# Altium schematic sheet ProperBMS.SchDoc(ProperBMS)
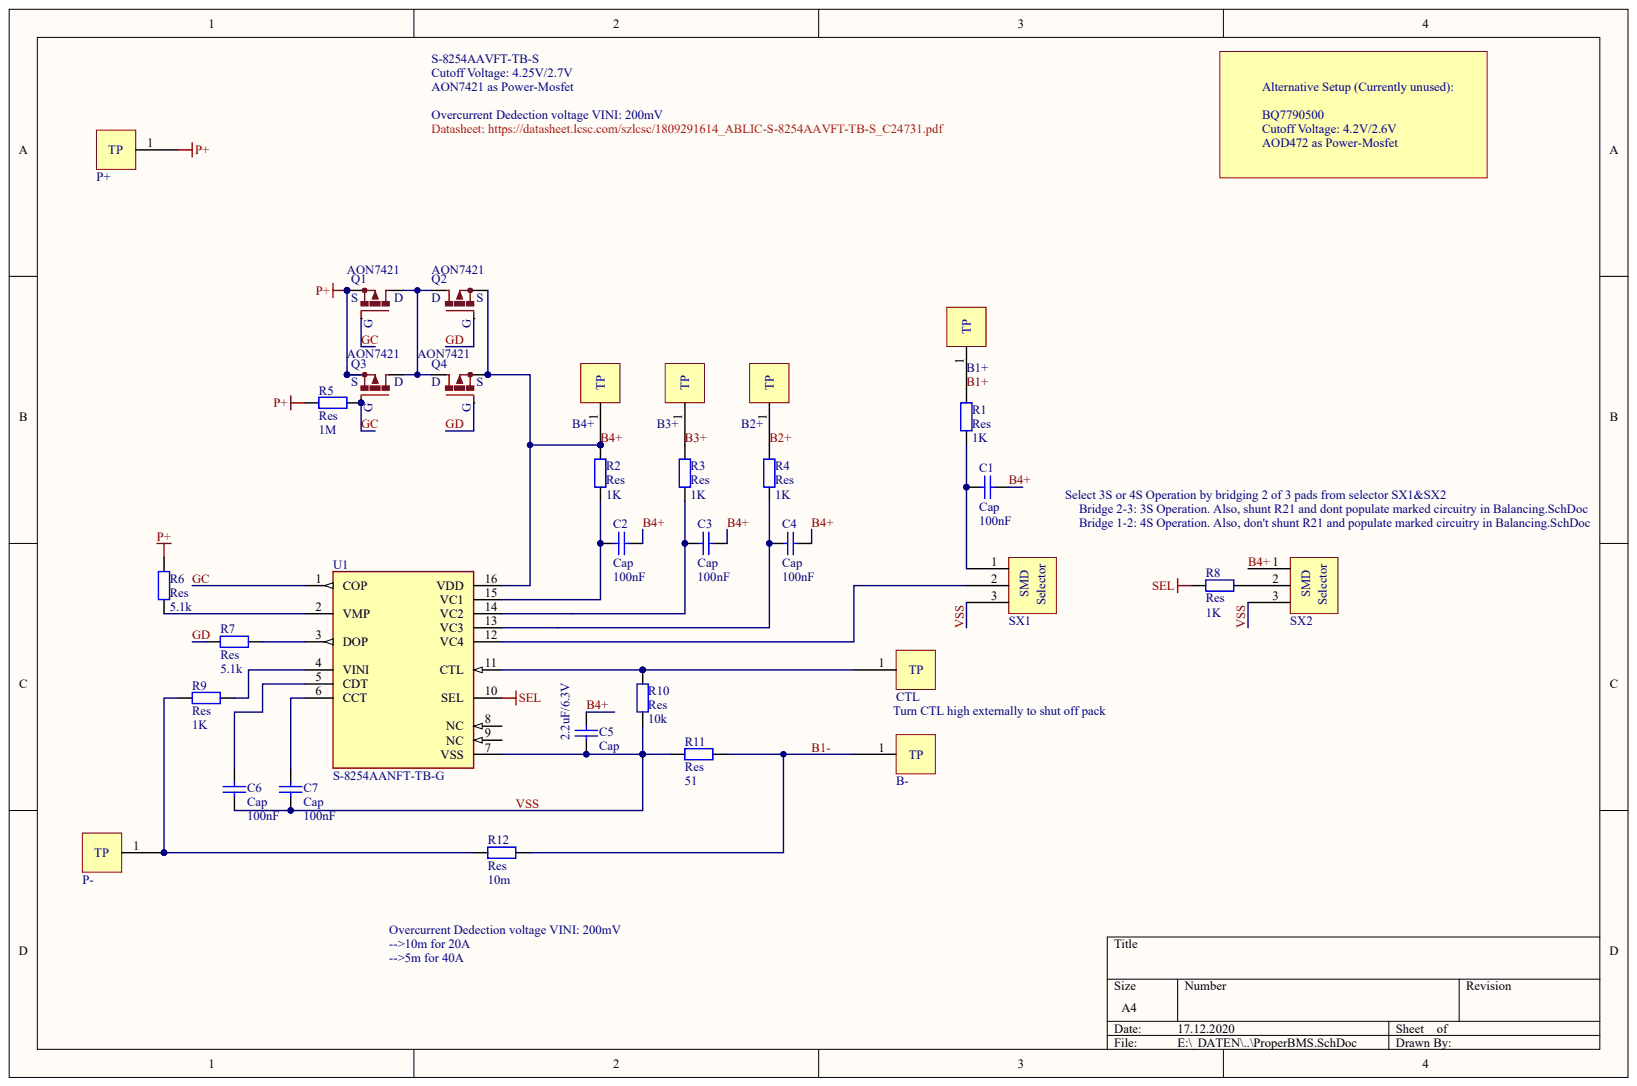
[diagram p0: ProperBMS.SchDoc]

## Parts
B1+  TestPoint  (value not drawn)
B2+  TestPoint  (value not drawn)
B3+  TestPoint  (value not drawn)
B4+  TestPoint  (value not drawn)
B-  TestPoint  (value not drawn)
C1  Cap  value 100nF
C2  Cap  value 100nF
C3  Cap  value 100nF
C4  Cap  value 100nF
C5  Cap  value 2.2uF/6.3V
C6  Cap  value 100nF
C7  Cap  value 100nF
CTL  TestPoint  (value not drawn)
P+  TestPoint  (value not drawn)
P-  TestPoint  (value not drawn)
Q1  AON7421
Q2  AON7421
Q3  AON7421
Q4  AON7421
R1  Res  value 1K
R2  Res  value 1K
R3  Res  value 1K
R4  Res  value 1K
R5  Res  value 1M
R6  Res  value 5.1k
R7  Res  value 5.1k
R8  Res  value 1K
R9  Res  value 1K
R10  Res  value 10k
R11  Res  value 51
R12  Res  value 10m
SX1  SelectorX3  (value not drawn)
SX2  SelectorX3  (value not drawn)
U1  S-8254AANFT-TB-G

## Nets
P+: P+.1 Q1.S Q3.S R5.2 R6.1
SEL: R8.1 U1.10(SEL)
B1+: B1+.1 R1.2
B1-: B-.1 R11.2 R12.2
B2+: B2+.1 R4.2
B3+: B3+.1 R3.2
B4+: B4+.1 C1.1 C2.1 C3.1 C4.1 C5.2 Q2.S Q4.S R2.2 SX2.1 U1.16(VDD)
GC: Q1.G Q3.G R5.1 U1.1(COP)
GD: Q2.G Q4.G R7.1
VSS: C5.1 C6.2 C7.2 R10.1 R11.1 SX1.3 SX2.3 U1.7(VSS)
NetC1_2: C1.2 R1.1 SX1.1
NetC2_2: C2.2 R2.1 U1.15(VC1)
NetC3_2: C3.2 R3.1 U1.14(VC2)
NetC4_2: C4.2 R4.1 U1.13(VC3)
NetC6_1: C6.1 U1.5(CDT)
NetC7_1: C7.1 U1.6(CCT)
NetCTL_1: CTL.1 R10.2 U1.11(CTL)
NetP-_1: P-.1 R9.1 R12.1
NetQ1_D: Q1.D Q2.D Q3.D Q4.D
NetR6_2: R6.2 U1.2(VMP)
NetR7_2: R7.2 U1.3(DOP)
NetR8_2: R8.2 SX2.2
NetR9_2: R9.2 U1.4(VINI)
NetSX1_2: SX1.2 U1.12(VC4)

Not connected: U1 8(NC) 9(NC)

## Library data of the parts
Q1-Q4 = (no symbol name): MP: CSD17575Q3; MF: Texas Instruments; DigiKey-Purchase-URL: https://snapeda.com/shop?store=DigiKey&id=450643; Availability: Warning; Package: VSON-CLIP-8 Texas Instruments; Texas_Instruments-Purchase-URL: https://snapeda.com/shop?store=Texas+Instruments&id=450643; Mouser-Purchase-URL: https://snapeda.com/shop?store=Mouser&id=450643; SnapEDA_Link: https://www.snapeda.com/parts/CSD17575Q3/Texas%20Instruments/view-part/450643/?ref=snap; Price: None; Value: *
U1 = (no symbol name): MF: Ablic U.S.A. Inc.; Package: TSSOP-16 ABLIC; MP: S-8254AANFT-TB-G; Value: *; Availability: Unavailable; SnapEDA_Link: https://www.snapeda.com/parts/S-8254AANFT-TB-G/ABLIC%20U.S.A.%20Inc./view-part/2782020/?ref=snap; Price: None
B1+ B2+ B3+ B4+ B- C1-C7 CTL P+ P- R1-R12 SX1 SX2 = (no symbol name)
  C1-C7: Published: 8-Jun-2000; LatestRevisionDate: 17-Jul-2002; LatestRevisionNote: Re-released for DXP Platform.; PackageReference: RAD-0.3; PackageDescription: Radial Cap, Thru-Hole; 2 Leads; 0.3 in Pin Spacing
  R1-R12: Published: 8-Jun-2000; LatestRevisionDate: 17-Jul-2002; LatestRevisionNote: Re-released for DXP Platform.; PackageReference: AXIAL-0.4; PackageDescription: Axial Device, Thru-Hole; 2 Leads; 0.4 in Pin Spacing
  C1-C7 R1-R12: Publisher: Altium Limited
